# Altium schematic sheet MCTA_LCD_BTN.SchDoc(MCTA_LCD_BTN)
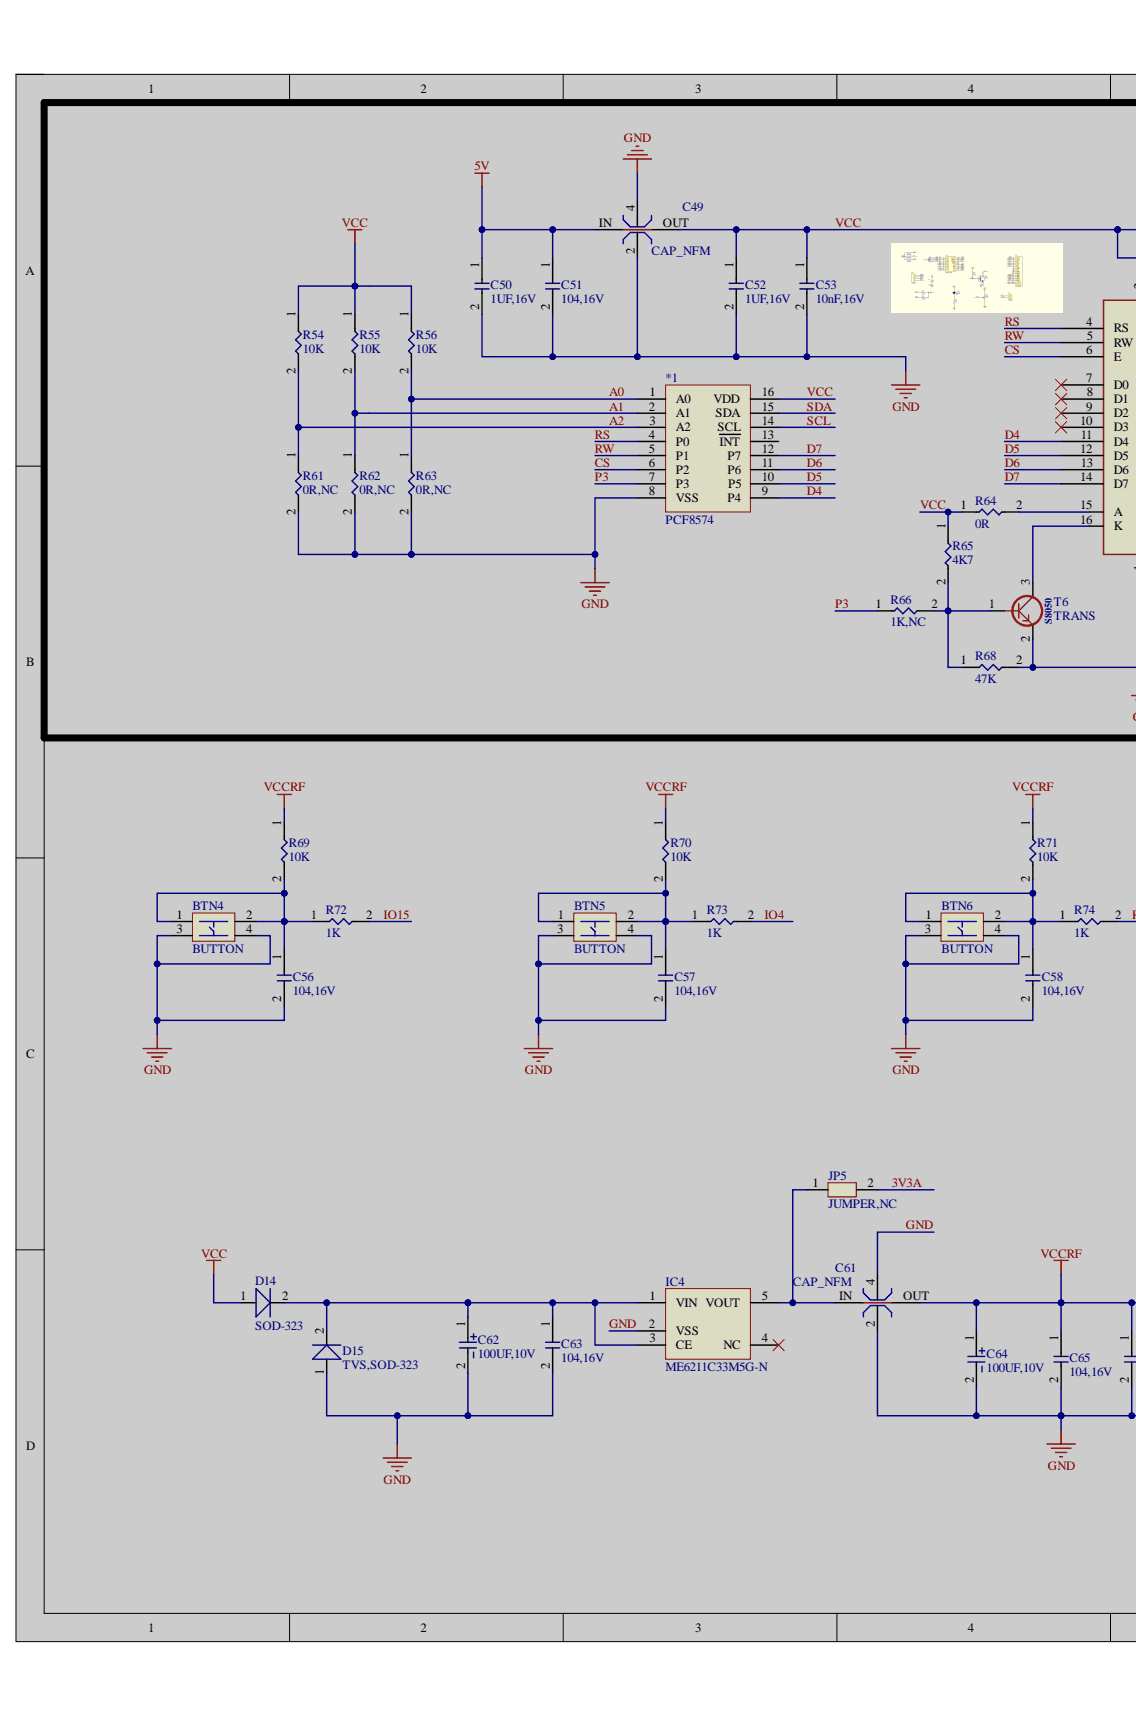
[diagram p0: MCTA_LCD_BTN.SchDoc, left]
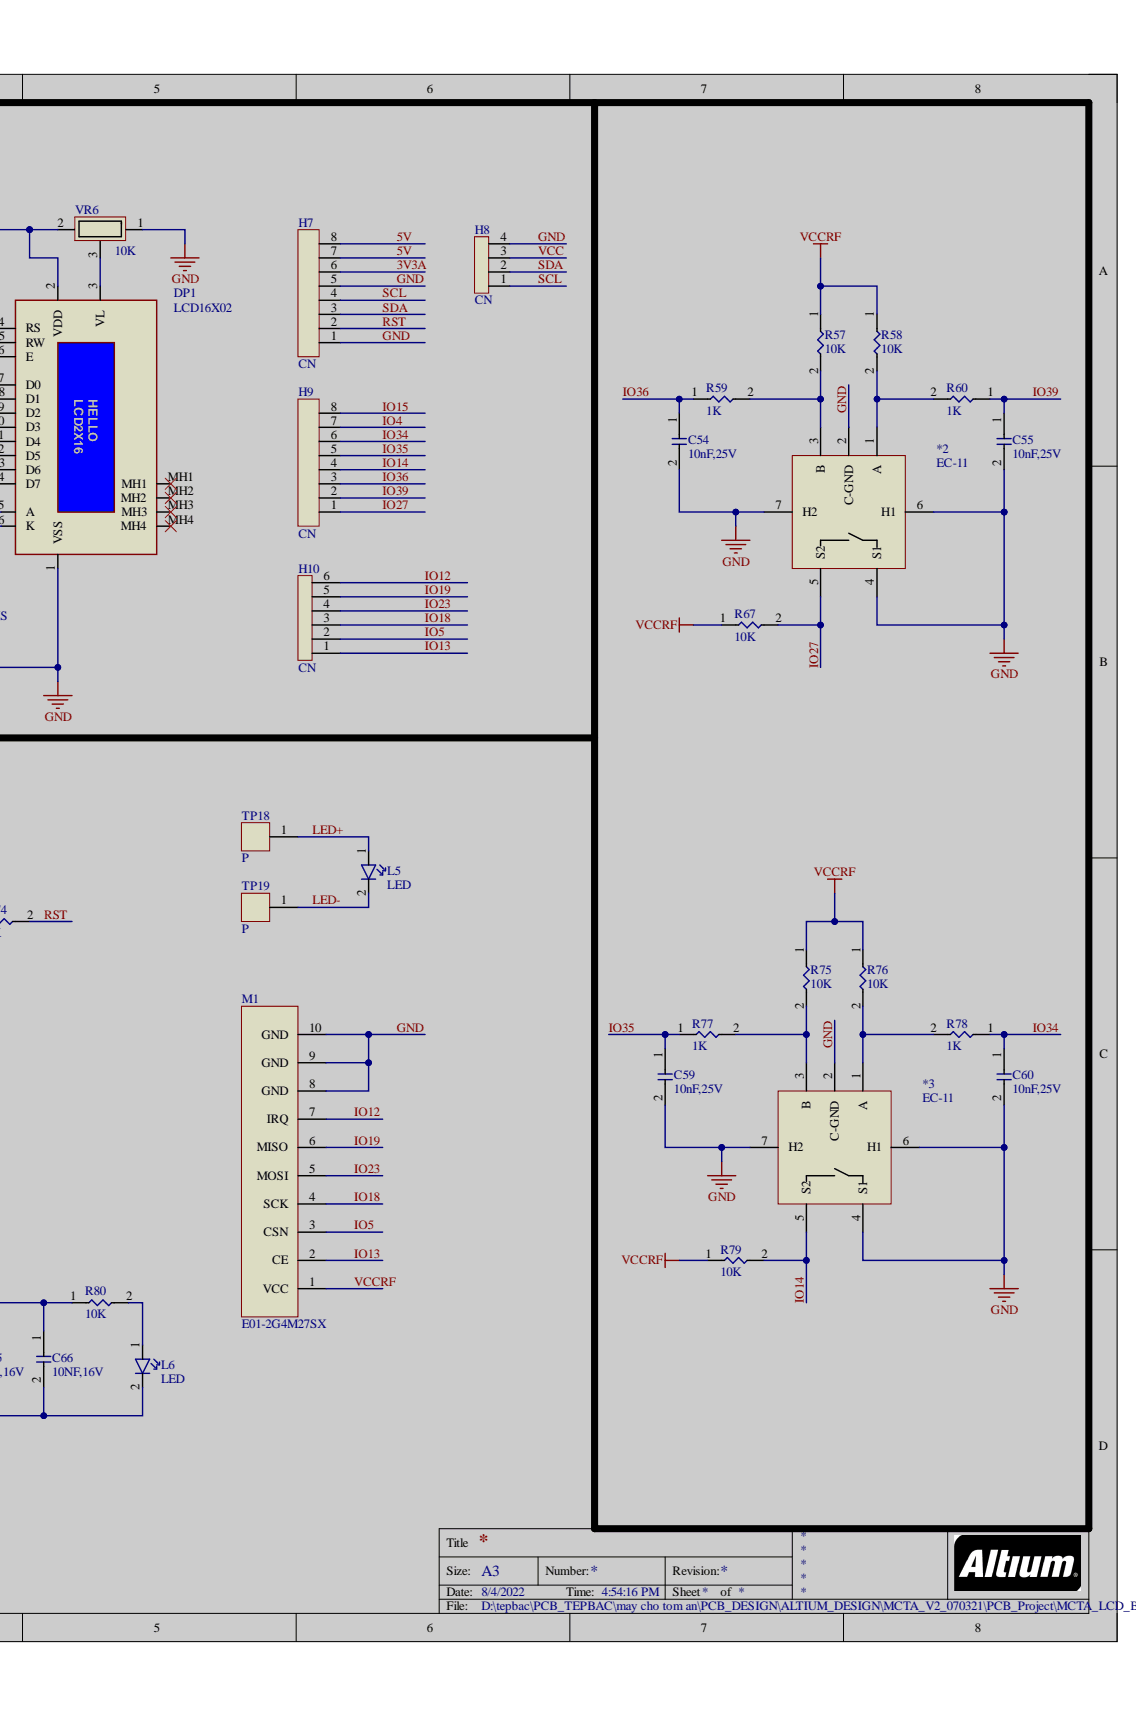
[diagram p1: MCTA_LCD_BTN.SchDoc, right]

## Parts
*1  PCF8574  [SO16]
*2  EC-11  [EC-11]
*3  EC-11  [EC-11]
BTN4  BUTTON  [6X6X8MM]
BTN5  BUTTON  [6X6X8MM]
BTN6  BUTTON  [6X6X8MM]
C49  CAP_NFM  [NFM21PC105B1A3D]
C50  1UF,16V  [cap_smd_0805]
C51  104,16V  [cap_smd_0603]
C52  1UF,16V  [cap_smd_0805]
C53  10nF,16V  [cap_smd_0603]
C54  10nF,25V  [cap_smd_0603]
C55  10nF,25V  [cap_smd_0603]
C56  104,16V  [cap_smd_0603]
C57  104,16V  [cap_smd_0603]
C58  104,16V  [cap_smd_0603]
C59  10nF,25V  [cap_smd_0603]
C60  10nF,25V  [cap_smd_0603]
C61  CAP_NFM  [NFM21PC105B1A3D]
C62  100UF,10V  [CAP_TAN_B]
C63  104,16V  [cap_smd_0603]
C64  100UF,10V  [CAP_TAN_B]
C65  104,16V  [cap_smd_0603]
C66  10NF,16V  [cap_smd_0603]
D14  SOD-323  [DIODE_SMD_SOD_323]
D15  TVS,SOD-323  [DIODE_SMD_SOD_323]
DP1  LCD16X02  [LCD16X02]
H7  CN  [8X01P_T]
H8  CN  [4P_DT_254MM]
H9  CN  [8X01P_T]
H10  CN  [6X01P_T]
IC4  ME6211C33M5G-N  [ME6211C33M5G-N]
JP5  JUMPER,NC  [jum_power]
L5  LED  [LED_3MM_DIP]
L6  LED  [LED_3MM_DIP]
M1  E01-2G4M27SX  [E01-2G4M27SX]
R54  10K  [0603_R_L]
R55  10K  [0603_R_L]
R56  10K  [0603_R_L]
R57  10K  [0603_R_L]
R58  10K  [0603_R_L]
R59  1K  [0603_R_L]
R60  1K  [0603_R_L]
R61  0R,NC  [0603_R_L]
R62  0R,NC  [0603_R_L]
R63  0R,NC  [0603_R_L]
R64  0R  [0805_R_L]
R65  4K7  [0603_R_L]
R66  1K,NC  [0603_R_L]
R67  10K  [0603_R_L]
R68  47K  [0603_R_L]
R69  10K  [0603_R_L]
R70  10K  [0603_R_L]
R71  10K  [0603_R_L]
R72  1K  [0603_R_L]
R73  1K  [0603_R_L]
R74  1K  [0603_R_L]
R75  10K  [0603_R_L]
R76  10K  [0603_R_L]
R77  1K  [0603_R_L]
R78  1K  [0603_R_L]
R79  10K  [0603_R_L]
R80  10K  [0603_R_L]
T6  TRANS  [S8050]
TP18  P  [TP]
TP19  P  [TP]
VR6  10K  [3362P]

## Nets
3V3A: H7.6 JP5.2
5V: C49.IN C50.1 C51.1 H7.7 H7.8
A0: *1.1(A0) R56.2 R63.1
A1: *1.2(A1) R55.2 R62.1
A2: *1.3(A2) R54.2 R61.1
CS: *1.6(P2) DP1.6(E)
D4: *1.9(P4) DP1.11(D4)
D5: *1.10(P5) DP1.12(D5)
D6: *1.11(P6) DP1.13(D6)
D7: *1.12(P7) DP1.14(D7)
GND: *1.8(VSS) *2.2(C-GND) *2.4(S1) *2.6(H1) *2.7(H2) *3.2(C-GND) *3.4(S1) *3.6(H1) *3.7(H2) BTN4.3 BTN4.4 BTN5.3 BTN5.4 BTN6.3 BTN6.4 C49.2 C49.4 C50.2 C51.2 C52.2 C53.2 C54.2 C55.2 C56.2 C57.2 C58.2 C59.2 C60.2 C61.2 C61.4 C62.2 C63.2 C64.2 C65.2 C66.2 D15.1 DP1.1(VSS) H7.1 H7.5 H8.4 IC4.2(VSS) L6.2 M1.8(GND) M1.9(GND) M1.10(GND) R61.2 R62.2 R63.2 R68.2 T6.2 VR6.1
IO4: H9.7 R73.2
IO5: H10.2 M1.3(CSN)
IO12: H10.6 M1.7(IRQ)
IO13: H10.1 M1.2(CE)
IO14: *3.5(S2) H9.4 R79.2
IO15: H9.8 R72.2
IO18: H10.3 M1.4(SCK)
IO19: H10.5 M1.6(MISO)
IO23: H10.4 M1.5(MOSI)
IO27: *2.5(S2) H9.1 R67.2
IO34: C60.1 H9.6 R78.1
IO35: C59.1 H9.5 R77.1
IO36: C54.1 H9.3 R59.1
IO39: C55.1 H9.2 R60.1
LED+: L5.1 TP18.1
LED-: L5.2 TP19.1
P3: *1.7(P3) R66.1
RS: *1.4(P0) DP1.4(RS)
RST: H7.2 R74.2
RW: *1.5(P1) DP1.5(RW)
SCL: *1.14(SCL) H7.4 H8.1
SDA: *1.15(SDA) H7.3 H8.2
VCC: *1.16(VDD) C49.OUT C52.1 C53.1 D14.1 DP1.2(VDD) H8.3 R54.1 R55.1 R56.1 R64.1 R65.1 VR6.2
VCCRF: C61.OUT C64.1 C65.1 C66.1 M1.1(VCC) R57.1 R58.1 R67.1 R69.1 R70.1 R71.1 R75.1 R76.1 R79.1 R80.1
Net*2_1: *2.1(A) R58.2 R60.2
Net*2_3: *2.3(B) R57.2 R59.2
Net*3_1: *3.1(A) R76.2 R78.2
Net*3_3: *3.3(B) R75.2 R77.2
NetBTN4_1: BTN4.1 BTN4.2 C56.1 R69.2 R72.1
NetBTN5_1: BTN5.1 BTN5.2 C57.1 R70.2 R73.1
NetBTN6_1: BTN6.1 BTN6.2 C58.1 R71.2 R74.1
NetC61_IN: C61.IN IC4.5(VOUT) JP5.1
NetC62_1: C62.1 C63.1 D14.2 D15.2 IC4.1(VIN) IC4.3(CE)
NetDP1_3: DP1.3(VL) VR6.3
NetDP1_15: DP1.15(A) R64.2
NetDP1_16: DP1.16(K) T6.3
NetL6_1: L6.1 R80.2
NetR65_2: R65.2 R66.2 R68.1 T6.1

Not connected: *1 13(INT); DP1 7(D0) 8(D1) 9(D2) 10(D3) MH1 MH2 MH3 MH4; IC4 4(NC)

## Library data of the parts
BTN4-BTN6 = BTN4P: Component Kind: Standard; Library Name: COMPONENT.IntLib
C50-C60 C63 C65 C66 = CAPACITOR: Component Kind: Standard; Library Name: COMPONENT.IntLib
C62 C64 = CAP_TAN: Component Kind: Standard; Library Name: COMPONENT.IntLib
D14 D15 = DIODE: Component Kind: Standard; Library Name: COMPONENT.IntLib
H7 H9 = 8x01p: Component Kind: Standard; Library Name: COMPONENT.IntLib
H8 = 4x01p: Component Kind: Standard; Library Name: COMPONENT.IntLib
H10 = 6x01p: Component Kind: Standard; Library Name: COMPONENT.IntLib
JP5 = JP_POWER: Component Kind: Standard; Library Name: COMPONENT.IntLib
R54-R80 = RESISTOR: Component Kind: Standard; Description: resistor; Library Name: COMPONENT.IntLib
T6 = S8050: Component Kind: Standard; Library Name: COMPONENT.IntLib
TP18 TP19 = TP: Component Kind: Standard; Library Name: COMPONENT.IntLib
VR6 = VRP: RR; Component Kind: Standard; ComponentLink1Description: VR-3362P; ComponentLink1URL: https://item.taobao.com/item.htm?spm=a312a.7700824.w4002-21223910190.12.1f6d40d3BuEv0x&id=532123981478; Library Name: COMPONENT.IntLib
*1 = PCF8574: Component Kind: Standard; Library Name: INTERFACE_CONVERTER.IntLib
*2 *3 = EC-11: Component Kind: Standard; Library Name: COMPONENT.IntLib
C49 C61 = CAP_NFM: Component Kind: Standard; Library Name: COMPONENT.IntLib
DP1 = LCD16X02: Component Kind: Standard; Library Name: LCD.IntLib
IC4 = ME6211C33M5G-N: Component Kind: Standard; Library Name: POWER_SUPPLY_ICs.IntLib
L5 L6 = LED: Component Kind: Standard; Library Name: COMPONENT.IntLib
M1 = E01-2G4M27SX: Component Kind: Standard; Library Name: MODULE.IntLib
